# Altium schematic sheet ActuatorSense.SchDoc(ActuatorSense)
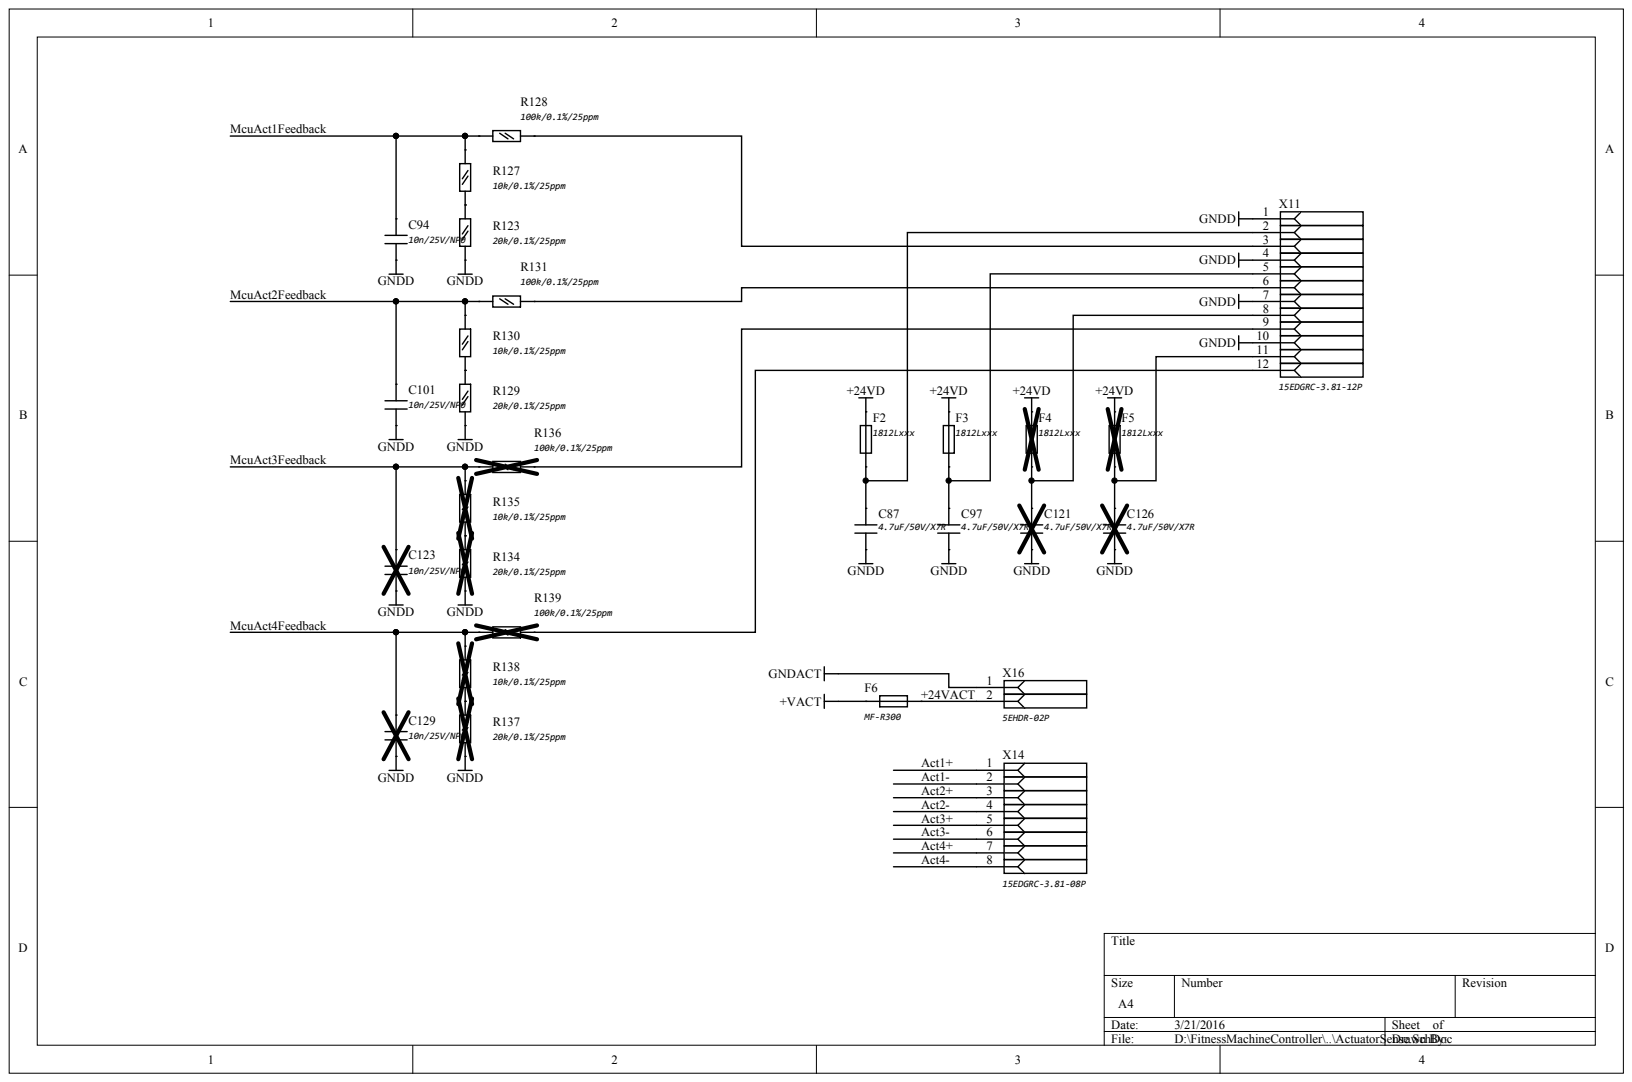
[diagram p0: ActuatorSense.SchDoc]

## Parts
C87  4.7uF/50V/X7R
C94  10n/25V/NP0
C97  4.7uF/50V/X7R
C101  10n/25V/NP0
C121  4.7uF/50V/X7R
C123  10n/25V/NP0
C126  4.7uF/50V/X7R
C129  10n/25V/NP0
F2  1812Lxxx
F3  1812Lxxx
F4  1812Lxxx
F5  1812Lxxx
F6  MF-R300
R123  20k/0.1%/25ppm
R127  10k/0.1%/25ppm
R128  100k/0.1%/25ppm
R129  20k/0.1%/25ppm
R130  10k/0.1%/25ppm
R131  100k/0.1%/25ppm
R134  20k/0.1%/25ppm
R135  10k/0.1%/25ppm
R136  100k/0.1%/25ppm
R137  20k/0.1%/25ppm
R138  10k/0.1%/25ppm
R139  100k/0.1%/25ppm
X11  15EDGRC-3.81-12P
X14  15EDGRC-3.81-08P
X16  5EHDR-02P

## Nets
+24VD: F2.2 F3.2 F4.2 F5.2
+VACT: F6.2
GNDACT: X16.1
GNDD: C87.1 C94.1 C97.1 C101.1 C121.1 C123.1 C126.1 C129.1 R123.1 R129.1 R134.1 R137.1 X11.1 X11.4 X11.7 X11.10
+24VACT: F6.1 X16.2
ACT1+: X14.1
ACT1-: X14.2
ACT2+: X14.3
ACT2-: X14.4
ACT3+: X14.5
ACT3-: X14.6
ACT4+: X14.7
ACT4-: X14.8
MCUACT1FEEDBACK: C94.2 R127.2 R128.1
MCUACT2FEEDBACK: C101.2 R130.2 R131.1
MCUACT3FEEDBACK: C123.2 R135.2 R136.1
MCUACT4FEEDBACK: C129.2 R138.2 R139.1
NetC87_2: C87.2 F2.1 X11.2
NetC97_2: C97.2 F3.1 X11.5
NetC121_2: C121.2 F4.1 X11.8
NetC126_2: C126.2 F5.1 X11.11
NetR123_2: R123.2 R127.1
NetR128_2: R128.2 X11.3
NetR129_2: R129.2 R130.1
NetR131_2: R131.2 X11.6
NetR134_2: R134.2 R135.1
NetR136_2: R136.2 X11.9
NetR137_2: R137.2 R138.1
NetR139_2: R139.2 X11.12

## Library data of the parts
C87 C97 C121 C126 = (no symbol name): ComponentDescriptionRUS: Конденсатор SMD 1210; Analog: нет; NumberSolderPointsSMD: 0; NumberSolderPointsTHT: 0
C94 C101 C123 C129 = (no symbol name): ComponentDescriptionRUS: Конденсатор SMD 0603; Analog: нет; NumberSolderPointsSMD: 0; NumberSolderPointsTHT: 0
F2-F6 = (no symbol name): ComponentDescriptionRUS: Предохранитель; Analog: нет; NumberSolderPointsSMD: 0; NumberSolderPointsTHT: 0
R123 R127-R131 R134-R139 = (no symbol name): ComponentDescriptionRUS: Резистор SMD 0805; Analog: нет; NumberSolderPointsSMD: 0; NumberSolderPointsTHT: 0
X11 = (no symbol name): Аналог: ECH381R-12P; ComponentDescriptionRUS: Клеммник разъемный 3.81мм; NumberSolderPointsSMD: 0; Комплект: EC381V-12P, 15EDGK-3.81-12; NumberSolderPointsTHT: 0
X14 = (no symbol name): Аналог: ECH381R-08P; ComponentDescriptionRUS: Клеммник разъемный 3.81мм; NumberSolderPointsSMD: 0; Комплект: EC381V-08P, 15EDGK-3.81-08; NumberSolderPointsTHT: 0
X16 = (no symbol name): Аналог: ECH381R-02P; NumberSolderPointsSMD: 0; Комплект: 5ESDV-02P; NumberSolderPointsTHT: 0
